# Altium schematic sheet Power Supplies and regulator.SchDoc
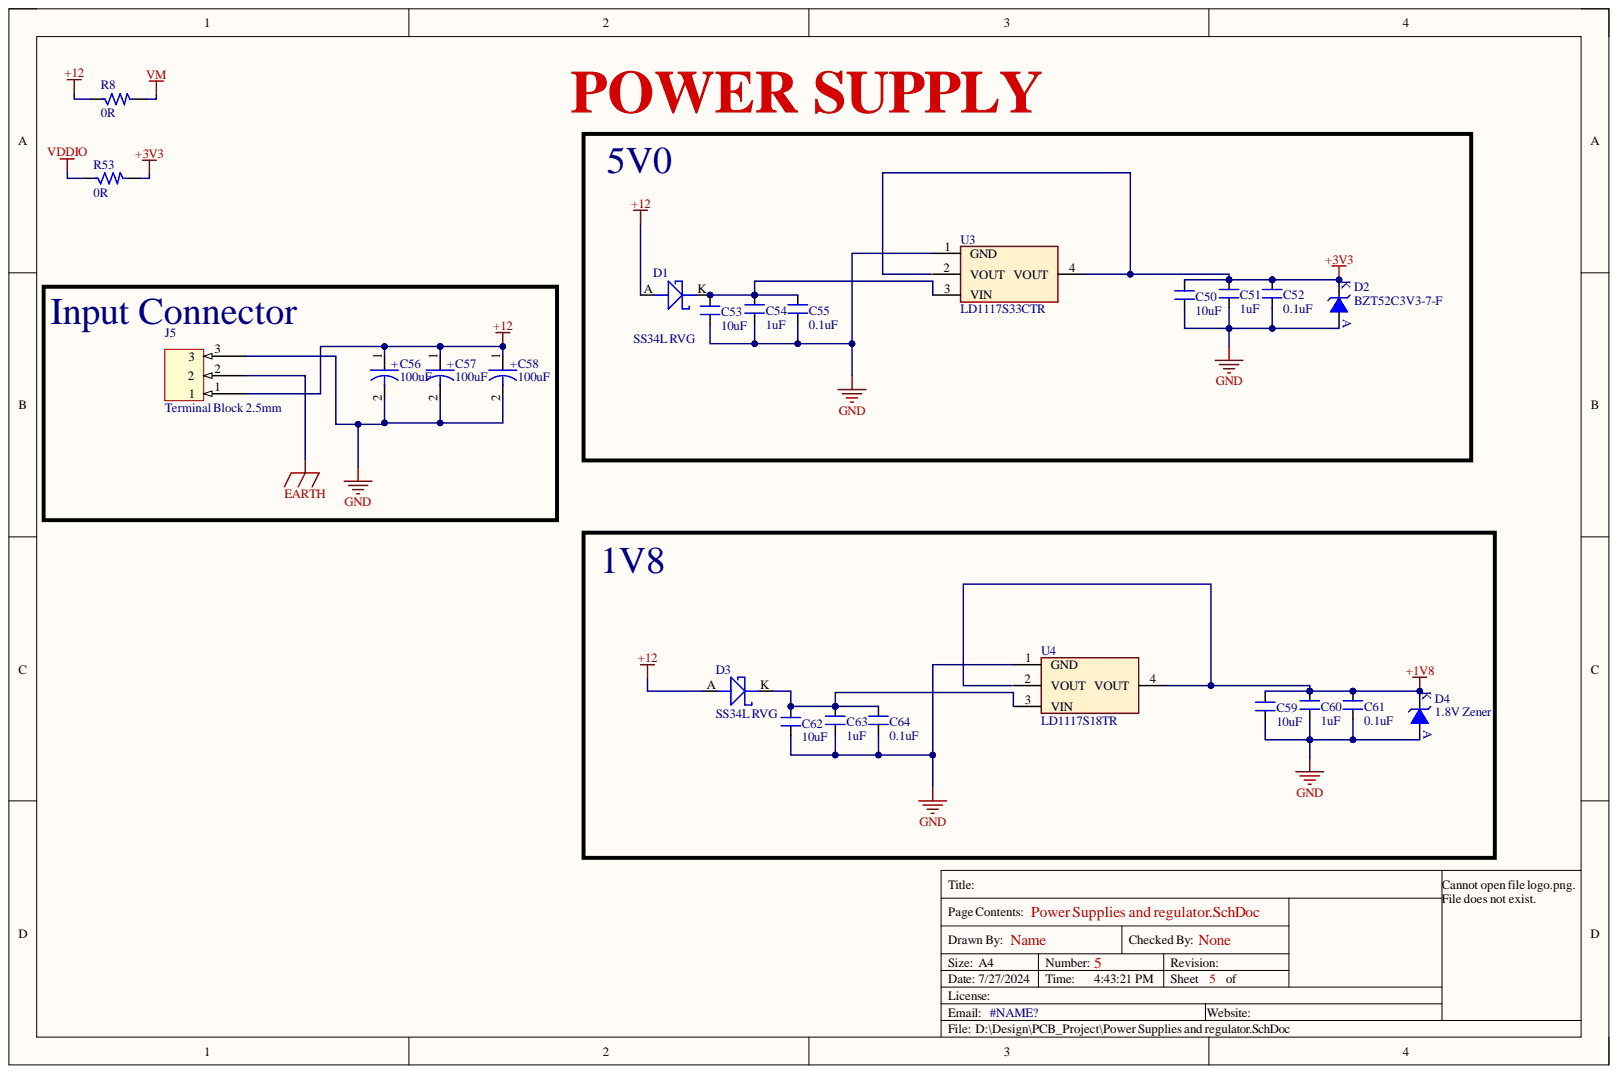
[diagram p0: Power Supplies and regulator.SchDoc]

## Parts
C50  10uF  [CAP_1206]
C51  1uF  [CAP_0805]
C52  0.1uF  [CAP_0805]
C53  10uF  [CAP_1206]
C54  1uF  [CAP_0805]
C55  0.1uF  [CAP_0805]
C56  100uF  [ACAP_P236_D305_H303_RAD]
C57  100uF  [ACAP_P236_D305_H303_RAD]
C58  100uF  [ACAP_P236_D305_H303_RAD]
C59  10uF  [CAP_1206]
C60  1uF  [CAP_0805]
C61  0.1uF  [CAP_0805]
C62  10uF  [CAP_1206]
C63  1uF  [CAP_0805]
C64  0.1uF  [CAP_0805]
D1  SS34L RVG  [DIO_SMB_DO-214AC]
D2  BZT52C3V3-7-F  [DIO_SOD-123]
D3  SS34L RVG  [DIO_SMB_DO-214AC]
D4  1.8V Zener  [DIO_DO-213AB_SMD]
J5  Terminal Block 2.5mm  [CON3_100_TB_TH_ST]
R8  0R  [RES_1206]
R53  0R  [RES_1206]
U3  LD1117S33CTR  [SOT223_3]
U4  LD1117S18TR  [SOT223_3]

## Nets
+1V8: C59.2 C60.2 C61.2 D4.K U4.4(VOUT)
+3V3: C50.2 C51.2 C52.2 D2.K R53.2 U3.4(VOUT)
+12: C56.1 C57.1 C58.1 D1.A D3.A J5.1 R8.1
EARTH: J5.2
GND: C50.1 C51.1 C52.1 C53.1 C54.1 C55.1 C56.2 C57.2 C58.2 C59.1 C60.1 C61.1 C62.1 C63.1 C64.1 D2.A D4.A J5.3 U3.1(GND) U4.1(GND)
VDDIO: R53.1
VM: R8.2
NetC53_2: C53.2 C54.2 C55.2 D1.K U3.3(VIN)
NetC62_2: C62.2 C63.2 C64.2 D3.K U4.3(VIN)
NetU3_2: U3.2(VOUT)
NetU4_2: U4.2(VOUT)

## Library data of the parts
C50 C53 C59 C62 = CAP_10uF-50V-10%-1206: 10µF ±10% 50V Ceramic Capacitor X7R 1206 (3216 Metric); Alternate Part Number-1: GRT31CR61H106ME01L; Manufacturer: KEMET; Manufacturer Part Number: CL31B106KBHNNNE; Case/Package: 1206; Component Kind: Standard; Library Name: ESTEEMPCBLIB.IntLib; Supplier Part Number-1: CC1206KKX5R9BB106; Supplier-1: SIRICOM
C51 C54 C60 C63 = CAP_1uF-50V-5%-0805: 1µF ±5% 50V Ceramic Capacitor X7R 0805 (2012 Metric); Alternate Part Number-1: 0805F105M500NT; Manufacturer: Samsung; Manufacturer Part Number: CC0805JKX7R9BB105; Case/Package: 0805; Component Kind: Standard; Library Name: ESTEEMPCBLIB.IntLib; Supplier Part Number-1: CL21B105KBFNNNE; Supplier-1: SIRICOM
C52 C55 C61 C64 = CAP_0.1uF-50V-5%-0805: 0.1µF ±5% 50V Ceramic Capacitor X7R 0805 (2012 Metric); Alternate Part Number-1: 0805B104M500; Manufacturer: AVX Corporation; Manufacturer Part Number: 08055C104JAT4A; Case/Package: 0805; Component Kind: Standard; Library Name: ESTEEMPCBLIB.IntLib; Supplier Part Number-1: 0805B104J500CT; Supplier-1: SIRICOM
C56-C58 = CAP-P_100uF-25V-20%-RAD: 100µF 25V Aluminum Electrolytic Capacitors Radial, Can - SMD 1000 Hrs @ 105°C; Alternate Part Number-1: NA; Manufacturer: Nichicon; Manufacturer Part Number: UWT1E101MCL1GS; Case/Package: Radial-SMD; Component Kind: Standard; Library Name: ESTEEMPCBLIB.IntLib; Supplier Part Number-1: UWT1E101MCL1GS; Supplier-1: SP ROAD
D1 D3 = DIO-S_550mV-40V-5A-SMC: Diode Schottky 40V 5A Surface Mount SMA; Alternate Part Number-1: SS34; Manufacturer: Multicomp; Case/Package: SMC; Component Kind: Standard; Library Name: ESTEEMPCBLIB.IntLib; Manufacturer Part Number: SS34L RVG; Supplier Part Number-1: SS34L RVG; Supplier-1: SIRICOM
D2 = DIO-Z_3.3V-500mW-SOD123: Zener Diode 3.3V 500mW ±6% Surface Mount SOD-123; Alternate Part Number-1: NA; Manufacturer: Diodes Incorporated; Case/Package: SOD-123; Component Kind: Standard; Library Name: ESTEEMPCBLIB.IntLib; Manufacturer Part Number: BZT52C3V3-7-F; Supplier Part Number-1: BZT52C3V3-FDICT-ND; Supplier-1: Digikey
D4 = DIO-Z_1.8V-500mW-DO213AA: Zener Diode 1.8V 500mW ±5% Surface Mount DO-213AA; Component Kind: Standard; Library Name: ESTEEMPCBLIB.IntLib
J5 = CON-TB_3X1-100-ST-TH: 3 Pins, 1 Row 2.54mm Pitch, straight Connector, male; Alternate Part Number-1: NA; Manufacturer: Phoenix Contact; Manufacturer Part Number: 1725669; Case/Package: TH-CON; Component Kind: Standard; Library Name: ESTEEMPCBLIB.IntLib; Supplier Part Number-1: 1725669; Supplier-1: SP ROAD
R8 R53 = RES_0R-0.25W-1%-1206: 0 Ohms Jumper 0.25W, 1/4W Chip Resistor 1206 (3216 Metric) Automotive AEC-Q200 Thick Film; Alternate Part Number-1: NA; Manufacturer: Walsin; Manufacturer Part Number: WR12X000PTL; Case/Package: 1206; Component Kind: Standard; Library Name: ESTEEMPCBLIB.IntLib; Supplier Part Number-1: WR12X000PTL; Supplier-1: SIRICOM
U3 = REG_15V-3.3V-0.9A-12W-SOT223: Linear Voltage Regulator IC 1 Output 800mA SOT-223; Alternate Part Number-1: NA; Manufacturer: STMicroelectronics; Case/Package: SOT223; Component Kind: Standard; Library Name: ESTEEMPCBLIB.IntLib; Manufacturer Part Number: LD1117S33CTR; Supplier Part Number-1: LD1117S33CTR; Supplier-1: SP ROAD
U4 = REG_15V-1.8V-0.8A-1.4W-SOT223: LDO Voltage Regulators 1.8V 0.8A Positive; Alternate Part Number-1: NA; Manufacturer: STMicroelectronics; Case/Package: SOT-223-3; Component Kind: Standard; Library Name: ESTEEMPCBLIB.IntLib; Manufacturer Part Number: LD1117S18TR; Supplier Part Number-1: 511-LD1117S18-TR; Supplier-1: Mouser
